# Altium schematic sheet FlexEMG_v0r0_RADIO.SchDoc
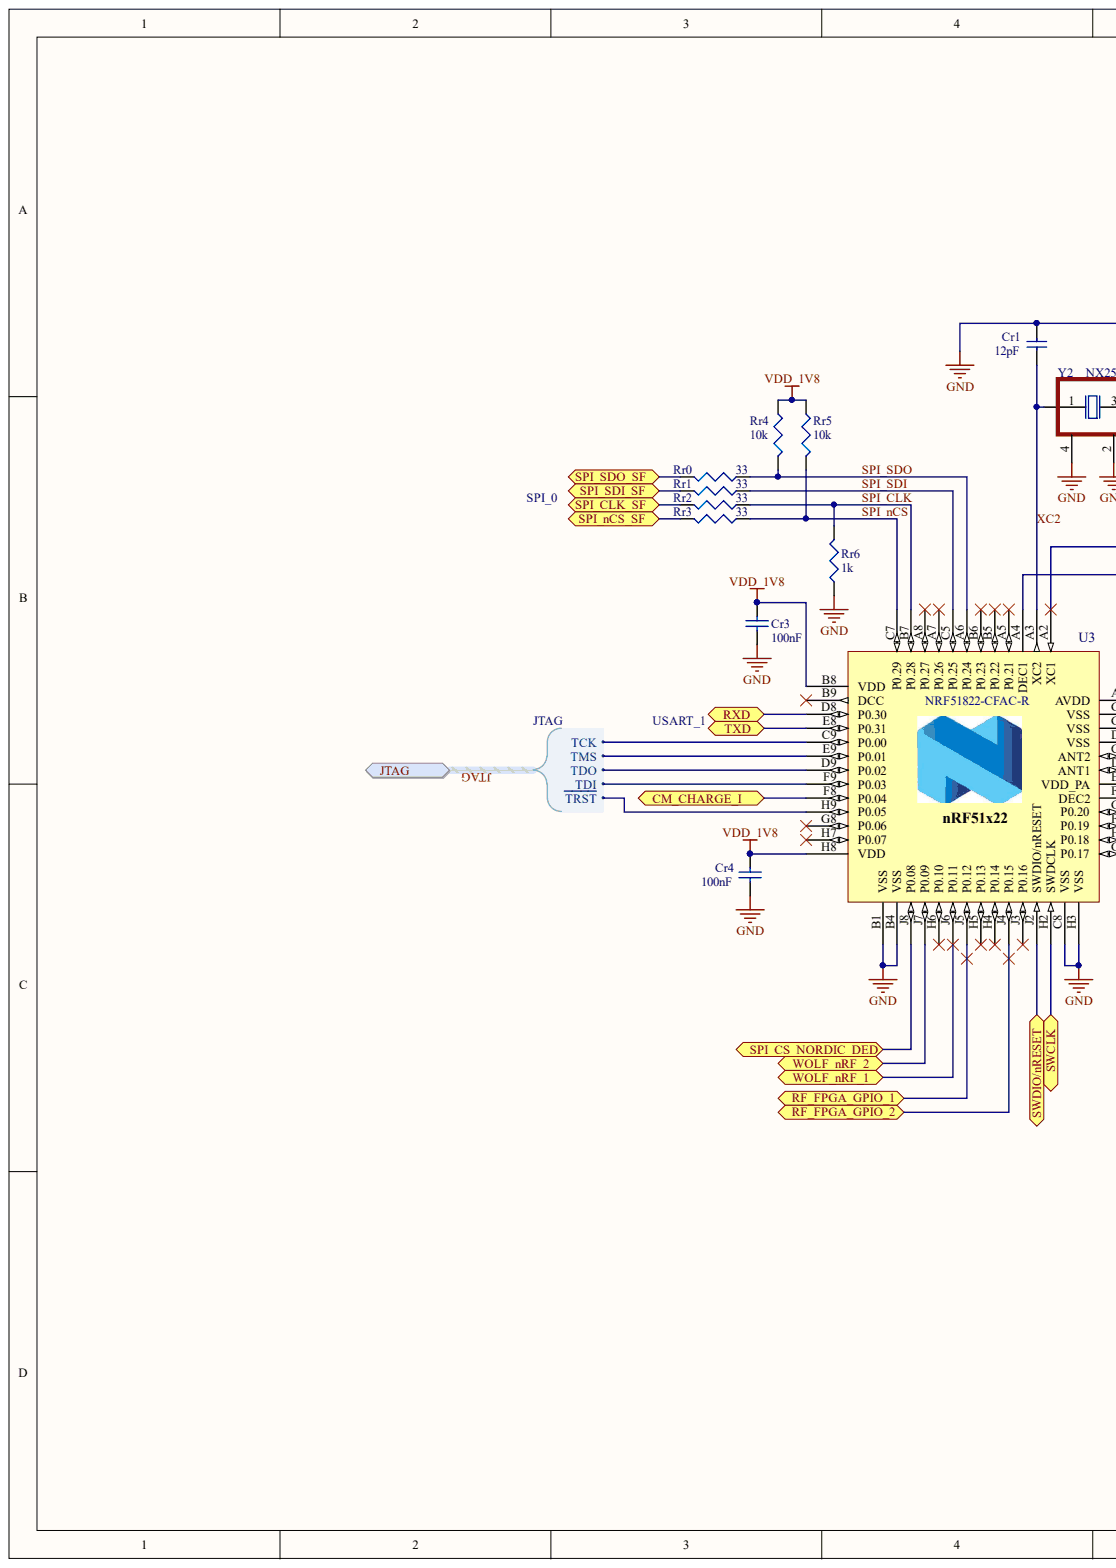
[diagram p0: FlexEMG_v0r0_RADIO.SchDoc, left]
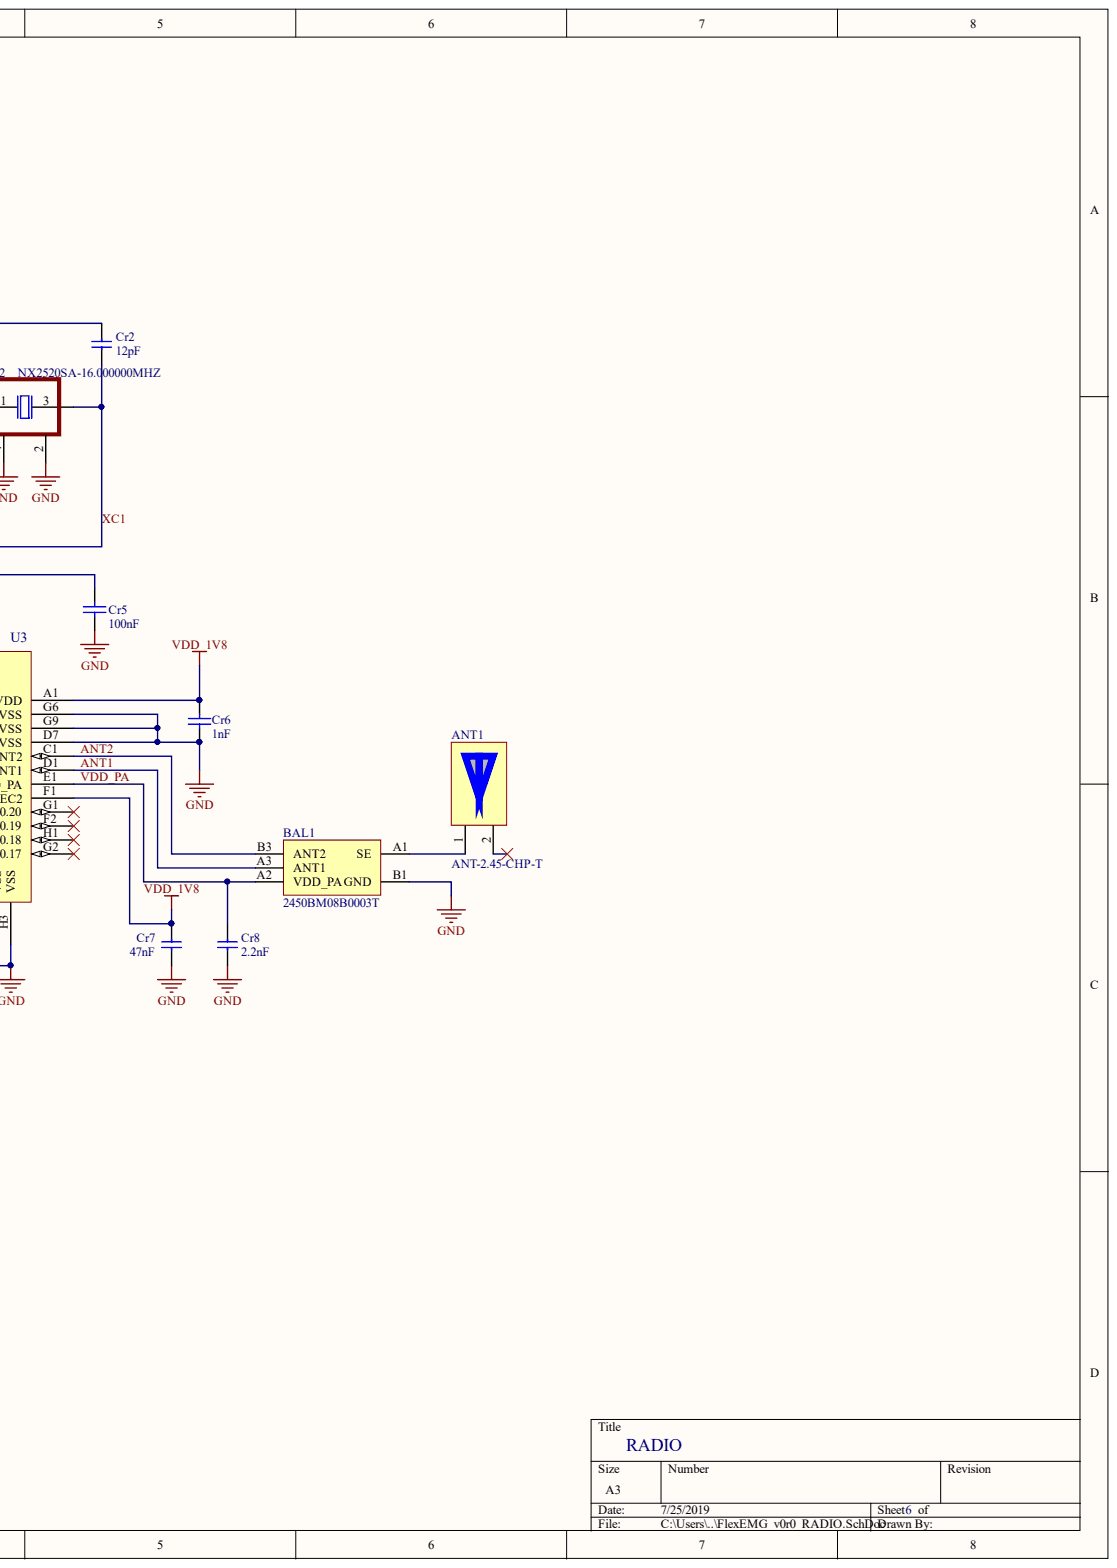
[diagram p1: FlexEMG_v0r0_RADIO.SchDoc, right]

## Parts
ANT1  ANT-2.45-CHP-T  [ANTENNACHIP]
BAL1  2450BM08B0003T  [BALUN_PACKAGE]
Cr1  value 12pF  [c0402-Murata-GR]  (hidden comment: GRM1555C1H120FA01D)
Cr2  value 12pF  [c0402-Murata-GR]  (hidden comment: GRM1555C1H120FA01D)
Cr3  value 100nF  [0201]  (hidden comment: GRM033R6YA104KE14D)
Cr4  value 100nF  [c0402-Murata-GR]  (hidden comment: GRM155R61H104KE19D)
Cr5  value 100nF  [0201]  (hidden comment: GRM033R6YA104KE14D)
Cr6  value 1nF  [c0402-Murata-GR]  (hidden comment: GRM155R61H102KA01D)
Cr7  value 47nF  [c0402-Murata-GR]  (hidden comment: GRM155R71E473KA88D)
Cr8  value 2.2nF  [c0402-Murata-GR]
Rr0  value 33  [0201]  (hidden comment: ERJ-PA2J330X)
Rr1  value 33  [0201]  (hidden comment: ERJ-PA2J330X)
Rr2  value 33  [0201]  (hidden comment: ERJ-PA2J330X)
Rr3  value 33  [0201]  (hidden comment: ERJ-PA2J330X)
Rr4  value 10k  [0201]  (hidden comment: ERJ-2RKF1002X)
Rr5  value 10k  [0402_p]  (hidden comment: ERJ-2RKF1002X)
Rr6  value 1k  [0201]  (hidden comment: ERJ-2RKF1001X)
U3  NRF51822-CFAC-R  [BGA_NORDIC]
Y2  NX2520SA-16.000000MHZ  [XOSC_4PIN]

## Ports
CM_CHARGE_I, JTAG, JTAG.TCK, JTAG.TDI, JTAG.TDO, JTAG.TMS, JTAG.~{TRST}, RF_FPGA_GPIO_1, RF_FPGA_GPIO_2, RXD, SPI_CLK_SF, SPI_CS_NORDIC_DED, SPI_NCS_SF, SPI_SDI_SF, SPI_SDO_SF, SWCLK, SWDIO/NRESET, TXD, WOLF_NRF_1, WOLF_NRF_2

## Nets
GND: BAL1.B1(GND) Cr1.2 Cr2.2 Cr3.1 Cr4.1 Cr5.1 Cr6.2 Cr7.1 Cr8.1 Rr6.1 U3.B1(VSS) U3.B4(VSS) U3.C8(VSS) U3.D7(VSS) U3.G6(VSS) U3.G9(VSS) U3.H3(VSS) Y2.2 Y2.4
VDD_1V8: Cr3.2 Cr4.2 Cr6.1 Cr7.2 Rr4.1 Rr5.1 U3.A1(AVDD) U3.B8(VDD) U3.F1(DEC2) U3.H8(VDD)
ANT1: BAL1.A3(ANT1) U3.D1(ANT1)
ANT2: BAL1.B3(ANT2) U3.C1(ANT2)
CM_CHARGE_I: U3.F8(P0.04) ; port CM_CHARGE_I
JTAG.~{TRST}: U3.H9(P0.05) ; port JTAG
JTAG.TCK: U3.C9(P0.00) ; port JTAG
JTAG.TDI: U3.F9(P0.03) ; port JTAG
JTAG.TDO: U3.D9(P0.02) ; port JTAG
JTAG.TMS: U3.E9(P0.01) ; port JTAG
RF_FPGA_GPIO_1: U3.J5(P0.12) ; port RF_FPGA_GPIO_1
RF_FPGA_GPIO_2: U3.J4(P0.15) ; port RF_FPGA_GPIO_2
RXD: U3.D8(P0.30) ; port RXD
SPI_CLK: Rr2.1 Rr6.2 U3.B7(P0.28)
SPI_CLK_SF: Rr2.2 ; port SPI_CLK_SF
SPI_CS_NORDIC_DED: U3.J8(P0.08) ; port SPI_CS_NORDIC_DED
SPI_NCS: Rr3.1 Rr5.2 U3.C7(P0.29)
SPI_SDI: Rr1.1 U3.C5(P0.25)
SPI_SDI_SF: Rr1.2 ; port SPI_SDI_SF
SPI_SDO: Rr0.1 Rr4.2 U3.A6(P0.24)
SPI_SDO_SF: Rr0.2 ; port SPI_SDO_SF
SWCLK: U3.H2(SWDCLK) ; port SWCLK
TXD: U3.E8(P0.31) ; port TXD
VDD_PA: BAL1.A2(VDD_PA) Cr8.2 U3.E1(VDD_PA)
XC1: Cr2.1 U3.A2(XC1) Y2.3
XC2: Cr1.1 U3.A3(XC2) Y2.1
NetANT1_1: ANT1.1 BAL1.A1(SE)
NetCr5_2: Cr5.2 U3.A4(DEC1)
NetRr3_2: Rr3.2 ; port SPI_NCS_SF
NetU3_J2: U3.J2(SWDIO/nRESET) ; port SWDIO/NRESET
NetU3_J6: U3.J6(P0.11) ; port WOLF_NRF_1
NetU3_J7: U3.J7(P0.09) ; port WOLF_NRF_2

Not connected: ANT1 2; U3 A5(P0.21) A7(P0.26) A8(P0.27) B5(P0.22) B6(P0.23) B9(DCC) F2(P0.19) G1(P0.20) G2(P0.17) G8(P0.06) H1(P0.18) H4(P0.14) H5(P0.13) H6(P0.10) H7(P0.07) J3(P0.16)

## Library data of the parts
ANT1 = Linx ANT-2.45-CHP-x: ANT 2.4GHZ 802.11 BLUETOOTH SMD; Manufacturer: Linx; Component Kind: Standard; Library Name: CM.SchLib; Manufacturer PN: ANT-2.45-CHP-T; Supplier: Digikey; Supplier PN: ANT-2.45-CHPCT-ND
BAL1 = BAL_NRF02D3: BALUN 2.4GHZ; Manufacturer: Johnson; Component Kind: Standard; Library Name: CM.SchLib; Manufacturer PN: 2450BM08B0003T; Supplier: Digikey; Supplier PN: 712-1582-1-ND
Cr1 Cr2 = (no symbol name): CAP CER 12PF 50V NP0 0402; Manufacturer: Murata; Component Kind: Standard; Library Name: Custom.SchLib; Manufacturer PN: GRM1555C1H120FA01D; Supplier: Digikey; Supplier PN: 490-6196-1-ND
Cr3 Cr5 = Cap: CAP CER 0.1UF 16V X7S 0201; Manufacturer: Murata; Note: 35V 10% X5R; Component Kind: Standard; Library Name: Custom.IntLib; Manufacturer PN: GRM033R6YA104KE14D; Signal Integrity: Cap; Simulation: CAP; Supplier: Digikey; Supplier PN: 490-10430-1-ND
Cr4 = Cap: CAP CER 0.1UF 50V X5R 0402; Manufacturer: Murata; Component Kind: Standard; Library Name: Custom.IntLib; Manufacturer PN: GRM155R61H104KE19D; Signal Integrity: Cap; Simulation: CAP; Supplier: Digikey; Supplier PN: 490-11917-1-ND
Cr6 = Cap: CAP CER 1000PF 50V X5R 0402; Manufacturer: Murata; Component Kind: Standard; Library Name: Custom.IntLib; Manufacturer PN: GRM155R61H102KA01D; Signal Integrity: Cap; Simulation: CAP; Supplier: Digikey; Supplier PN: 490-6316-1-ND
Cr7 = (no symbol name): CAP CER 0.047UF 25V X7R 0402 10%; Manufacturer: Murata; Component Kind: Standard; Library Name: Custom.SchLib; Manufacturer PN: GRM155R71E473KA88D; Supplier: Digikey; Supplier PN: 490-3254-1-ND
Cr8 = (no symbol name): CAP CER 2200PF 50V X7R 0402; Manufacturer: Murata; Manufacturer PN: GRM155R71H222JA01J; Component Kind: Standard; Library Name: Custom.SchLib; Supplier: Digikey; Supplier PN: 490-6359-1-ND
Rr0-Rr3 = Resistor: RES SMD 33 OHM 1% 1/10W 0402; Manufacturer: Panasonic; Power: 1/5W; Component Kind: Standard; Library Name: Custom.SchLib; Manufacturer PN: ERJ-PA2J330X; Package: 0402; Signal Integrity: Res; Simulation: RESISTOR; Supplier: Digikey; Supplier P/N: P17184CT-ND
Rr4 Rr5 = Resistor: RES SMD 10K OHM 1% 1/10W 0402; Manufacturer: Panasonic; Component Kind: Standard; Library Name: Custom.SchLib; Manufacturer PN: ERJ-2RKF1002X; Package: 0402; Power: 1/10W; Signal Integrity: Res; Simulation: RESISTOR; Supplier: Digikey; Supplier P/N: P10.0KLCT-ND
Rr6 = Resistor: RES SMD 1K OHM 1% 1/10W 0402; Manufacturer: Panasonic; Component Kind: Standard; Library Name: Custom.SchLib; Manufacturer PN: ERJ-2RKF1001X; Package: 0402; Power: 1/10W; Signal Integrity: Res; Simulation: RESISTOR; Supplier: Digikey; Supplier P/N: P1.00KLCT-ND
U3 = nRF51822: IC SOC 2.4GHZ MULTIPROTO 62WLCS; Manufacturer: Nordic; Component Kind: Standard; Library Name: CM.SchLib; Manufacturer PN: NRF51822-CFAC-R; Supplier: Digikey; Supplier PN: 1490-1041-1-ND
Y2 = XOSC: CRYSTAL 16.0000MHZ 10PF SMD; Manufacturer: NDK; Component Kind: Standard; Library Name: CM.SchLib; Manufacturer PN: NX2520SA-16.000000MHZ; Supplier: Digikey; Supplier PN: 644-1059-1-ND
